# Altium schematic sheet Inverter.SchDoc(Inverter)
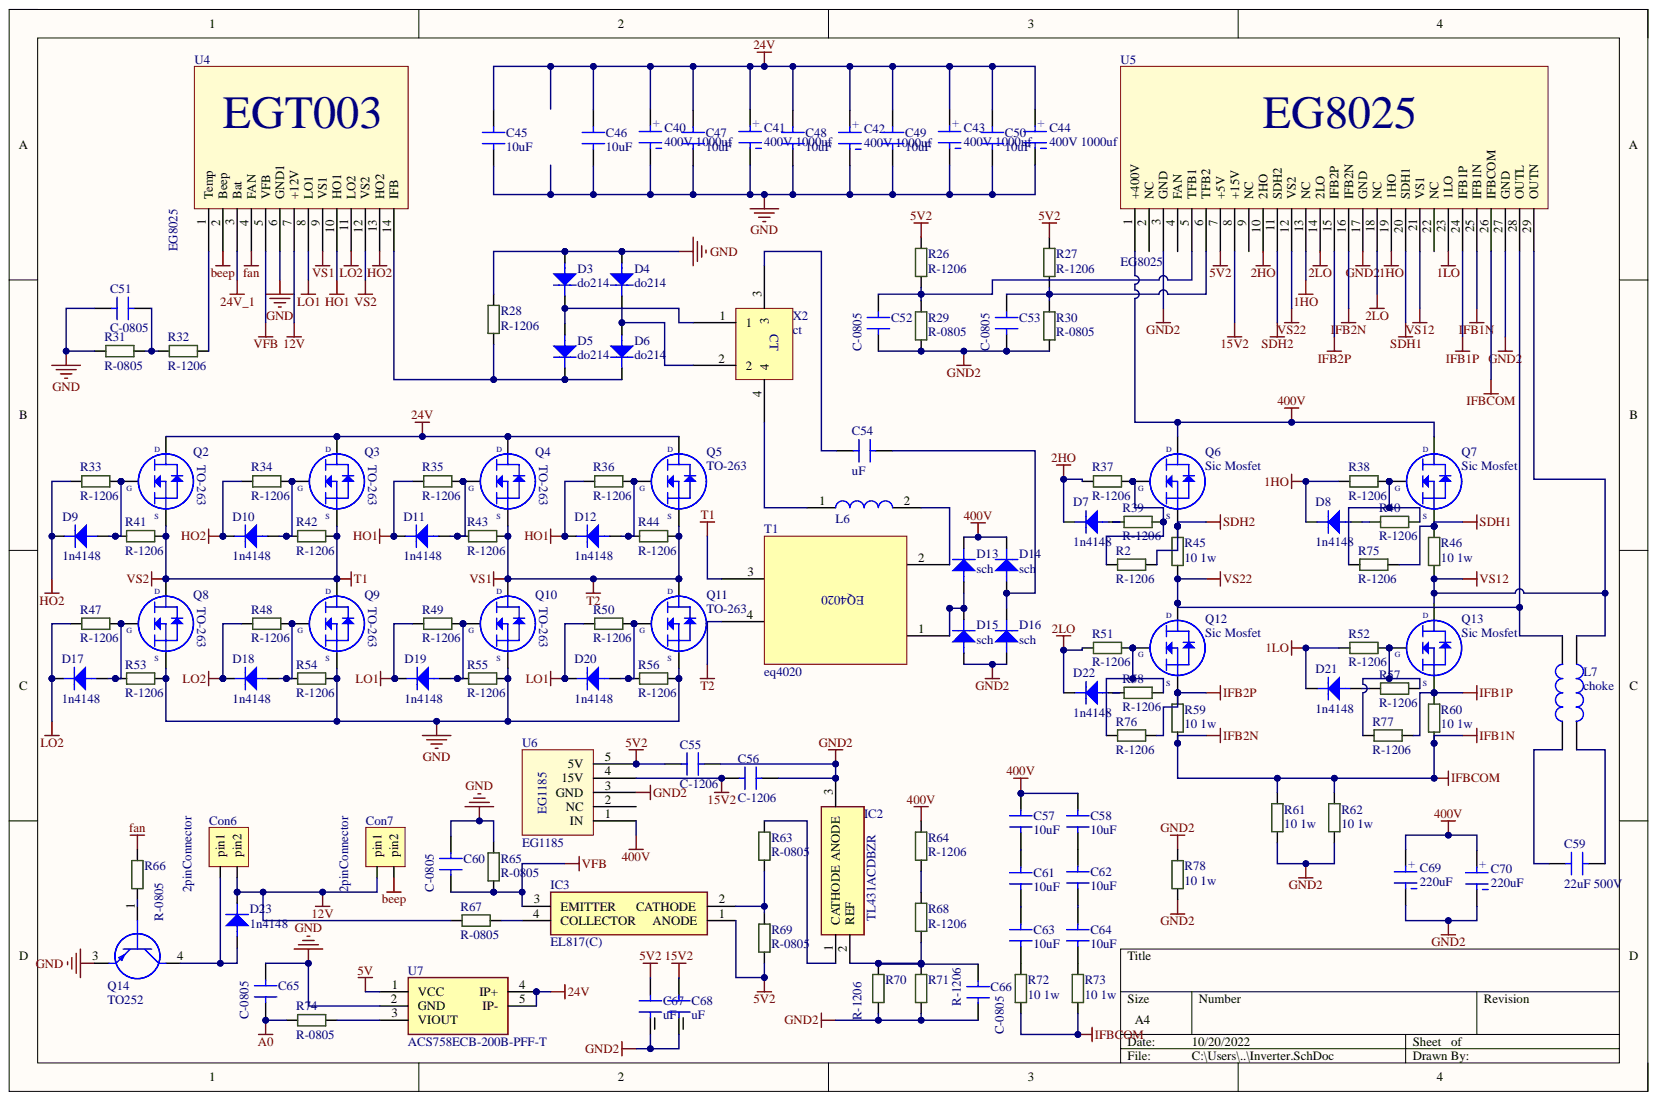
[diagram p0: Inverter.SchDoc]

## Parts
C40  400V 1000uf  [CAP_EEETC1C331V]
C41  400V 1000uf  [CAP_EEETC1C331V]
C42  400V 1000uf  [CAP_EEETC1C331V]
C43  400V 1000uf  [CAP_EEETC1C331V]
C44  400V 1000uf  [CAP_EEETC1C331V]
C45  10uF  [C-2220R]
C46  10uF  [C-2220R]
C47  10uF  [C-2220R]
C48  10uF  [C-2220R]
C49  10uF  [C-2220R]
C50  10uF  [C-2220R]
C51  C-0805  [C-0805W]
C52  C-0805  [C-0805W]
C53  C-0805  [C-0805W]
C54  uF  [680nF bulk]
C55  C-1206  [C-1206W]
C56  C-1206  [C-1206W]
C57  10uF  [C-2220R]
C58  10uF  [C-2220R]
C59  22uF 500V  [CAP.POL 22UF 500VDC - duplicate]
C60  C-0805  [C-0805W]
C61  10uF  [C-2220R]
C62  10uF  [C-2220R]
C63  10uF  [C-2220R]
C64  10uF  [C-2220R]
C65  C-0805  [C-0805W]
C66  C-0805  [C-0805W]
C67  uF  [CapSmdElc]
C68  uF  [CapSmdElc]
C69  220uF  [Koshin]
C70  220uF  [Koshin]
Con6  2pinConnector  [2pinCon]
Con7  2pinConnector  [2pinCon]
D3  do214  [DO-214AC]
D4  do214  [DO-214AC]
D5  do214  [DO-214AC]
D6  do214  [DO-214AC]
D7  1n4148  [SOD123]
D8  1n4148  [SOD123]
D9  1n4148  [SOD123]
D10  1n4148  [SOD123]
D11  1n4148  [SOD123]
D12  1n4148  [SOD123]
D13  sch  [DPAK_TO263 - sch]
D14  sch  [DPAK_TO263 - sch]
D15  sch  [DPAK_TO263 - sch]
D16  sch  [DPAK_TO263 - sch]
D17  1n4148  [SOD123]
D18  1n4148  [SOD123]
D19  1n4148  [SOD123]
D20  1n4148  [SOD123]
D21  1n4148  [SOD123]
D22  1n4148  [SOD123]
D23  1n4148  [SOD123]
IC2  TL431ACDBZR  [SOT95P237X112-3N]
IC3  EL817(C)  [DIP762W60P254L458H480Q4N]
L6  inducter_SMPI06050  [inductor]  (value not drawn)
L7  choke  [choke]
Q2  TO-263  [DPAK_TO263]
Q3  TO-263  [DPAK_TO263]
Q4  TO-263  [DPAK_TO263]
Q5  TO-263  [DPAK_TO263]
Q6  Sic Mosfet  [247]
Q7  Sic Mosfet  [247]
Q8  TO-263  [DPAK_TO263]
Q9  TO-263  [DPAK_TO263]
Q10  TO-263  [DPAK_TO263]
Q11  TO-263  [DPAK_TO263]
Q12  Sic Mosfet  [247]
Q13  Sic Mosfet  [247]
Q14  TO252  [D-PAK_TO252AA]
R2  R-1206  [R-1206W]
R26  R-1206  [R-1206W]
R27  R-1206  [R-1206W]
R28  R-1206  [R-1206W]
R29  R-0805  [R-0805W]
R30  R-0805  [R-0805W]
R31  R-0805  [R-0805W]
R32  R-1206  [R-1206W]
R33  R-1206  [R-1206W]
R34  R-1206  [R-1206W]
R35  R-1206  [R-1206W]
R36  R-1206  [R-1206W]
R37  R-1206  [R-1206W]
R38  R-1206  [R-1206W]
R39  R-1206  [R-1206W]
R40  R-1206  [R-1206W]
R41  R-1206  [R-1206W]
R42  R-1206  [R-1206W]
R43  R-1206  [R-1206W]
R44  R-1206  [R-1206W]
R45  10 1w  [R-2512W]
R46  10 1w  [R-2512W]
R47  R-1206  [R-1206W]
R48  R-1206  [R-1206W]
R49  R-1206  [R-1206W]
R50  R-1206  [R-1206W]
R51  R-1206  [R-1206W]
R52  R-1206  [R-1206W]
R53  R-1206  [R-1206W]
R54  R-1206  [R-1206W]
R55  R-1206  [R-1206W]
R56  R-1206  [R-1206W]
R57  R-1206  [R-1206W]
R58  R-1206  [R-1206W]
R59  10 1w  [R-2512W]
R60  10 1w  [R-2512W]
R61  10 1w  [R-2512W]
R62  10 1w  [R-2512W]
R63  R-0805  [R-0805W]
R64  R-1206  [R-1206W]
R65  R-0805  [R-0805W]
R66  R-0805  [R-0805W]
R67  R-0805  [R-0805W]
R68  R-1206  [R-1206W]
R69  R-0805  [R-0805W]
R70  R-1206  [R-1206W]
R71  R-1206  [R-1206W]
R72  10 1w  [R-2512W]
R73  10 1w  [R-2512W]
R74  R-0805  [R-0805W]
R75  R-1206  [R-1206W]
R76  R-1206  [R-1206W]
R77  R-1206  [R-1206W]
R78  10 1w  [R-2512W]
T1  eq4020  [eq4020]
U4  EG8025  [EGT003]
U5  EG8025  [EG8025]
U6  EG1185  [EG1185]
U7  ACS758ECB-200B-PFF-T  [ACS756SCA-050B-PFF-T_2]
X2  ct  [ct]

## Nets
1HO: D8.k R38.1 U5.13(NC) U5.19(1HO)
1LO: D21.k R52.1 U5.23(1LO)
2HO: D7.k R37.1 U5.10(2HO)
2LO: D22.k R51.1 U5.14(2LO) U5.18(NC)
5V: U7.1(VCC)
5V2: C55.1 C67.1 IC3.1(ANODE) R26.2 R27.2 R69.1 U5.7(+5V) U6.5(5V)
12V: Con6.2 Con7.1 D23.k R67.1 U4.7(+12V)
15V2: C56.1 C68.1 U5.8(+15V) U6.4(15V)
24V: C40.1 C41.1 C42.1 C43.1 C44.1 C45.1 C46.1 C47.1 C48.1 C49.1 C50.1 Q2.3 Q3.3 Q4.3 Q5.3 U7.4(IP+) U7.5(IP-)
24V_1: U4.3(Bat)
400V: C57.1 C58.1 C69.2 C70.2 D13.2 D14.2 Q6.2 Q7.2 R64.2 U5.1(+400V) U6.1(IN)
A0: C65.1 R74.2
beep: Con7.2 U4.2(Beep)
fan: R66.1 U4.4(FAN)
GND: C40.2 C41.2 C42.2 C43.2 C44.2 C45.2 C46.2 C47.2 C48.2 C49.2 C50.2 C51.1 C60.2 C65.2 D3.a D4.a Q8.2 Q9.2 Q10.2 Q11.2 Q14.3 R28.2 R31.1 R53.2 R54.2 R55.2 R56.2 R65.2 U4.6(GND1) U7.2(GND)
GND2: C52.1 C53.1 C55.2 C56.2 C66.1 C67.2 C68.2 C69.1 C70.1 D15.1 D16.1 IC2.3 R29.1 R30.1 R61.1 R62.1 R70.1 R71.1 R78.1 R78.2 U5.3(GND) U5.17(GND) U5.27(GND) U6.3(GND)
HO1: D11.k D12.k R35.1 R36.1 U4.10(HO1)
HO2: D9.k D10.k R33.1 R34.1 U4.13(HO2)
IFB1N: R59.1 R60.1 R61.2 R62.2 R72.1 R73.1 U5.16(IFB2N) U5.25(IFB1N) U5.26(IFBCOM)
IFB1P: Q13.3 R60.2 R77.1 U5.24(IFB1P)
IFB2P: Q12.3 R59.2 R76.1 U5.15(IFB2P)
LO1: D19.k D20.k R49.1 R50.1 U4.8(LO1)
LO2: D17.k D18.k R47.1 R48.1 U4.11(LO2)
SDH1: Q7.3 R46.2 R75.1 U5.20(SDH1)
SDH2: Q6.3 R2.1 R45.2 U5.11(SDH2)
T1: Q2.2 Q3.2 Q8.3 Q9.3 R41.2 R42.2 T1.3 U4.12(VS2)
T2: Q4.2 Q5.2 Q10.3 Q11.3 R43.2 R44.2 T1.4 U4.9(VS1)
VFB: C60.1 IC3.3(EMITTER) R65.1 U4.5(VFB)
VS12: L7.1 Q13.2 R46.1 U5.21(VS1) U5.29(OUTN)
VS22: L7.2 Q12.2 R45.1 U5.12(VS2) U5.28(OUTL)
NetC51_2: C51.2 R31.2 R32.1
NetC52_2: C52.2 R26.1 R29.2 U5.5(TFB1)
NetC53_2: C53.2 R27.1 R30.2 U5.6(TFB2)
NetC54_1: C54.1 X2.3
NetC54_2: C54.2 D14.1 D16.2
NetC57_2: C57.2 C61.1
NetC58_2: C58.2 C62.1
NetC59_1: C59.1 L7.4
NetC59_2: C59.2 L7.3
NetC61_2: C61.2 C63.1
NetC62_2: C62.2 C64.1
NetC63_2: C63.2 R72.2
NetC64_2: C64.2 R73.2
NetC66_2: C66.2 IC2.2 R68.1 R70.2 R71.2
NetCon6_1: Con6.1 D23.a Q14.4
NetD3_k: D3.k D5.a X2.1
NetD4_k: D4.k D6.a X2.2
NetD5_k: D5.k D6.k R28.1 U4.14(IFB)
NetD7_a: D7.a R39.1
NetD8_a: D8.a R40.1
NetD9_a: D9.a Q2.1 R33.2 R41.1
NetD10_a: D10.a Q3.1 R34.2 R42.1
NetD11_a: D11.a Q4.1 R35.2 R43.1
NetD12_a: D12.a Q5.1 R36.2 R44.1
NetD13_1: D13.1 D15.2 T1.1
NetD17_a: D17.a Q8.1 R47.2 R53.1
NetD18_a: D18.a Q9.1 R48.2 R54.1
NetD19_a: D19.a Q10.1 R49.2 R55.1
NetD20_a: D20.a Q11.1 R50.2 R56.1
NetD21_a: D21.a R57.1
NetD22_a: D22.a R58.1
NetIC2_1: IC2.1 R63.1
NetIC3_2: IC3.2(CATHODE) R63.2 R69.2
NetIC3_4: IC3.4(COLLECTOR) R67.2
NetL6_1: L6.1 X2.4
NetL6_2: L6.2 T1.2
NetQ6_1: Q6.1 R2.2 R37.2 R39.2
NetQ7_1: Q7.1 R38.2 R40.2 R75.2
NetQ12_1: Q12.1 R51.2 R58.2 R76.2
NetQ13_1: Q13.1 R52.2 R57.2 R77.2
NetQ14_1: Q14.1 R66.2
NetR32_2: R32.2 U4.1(Temp)
NetR64_1: R64.1 R68.2
NetR74_1: R74.1 U7.3(VIOUT)

Not connected: U5 2(NC) 4(FAN) 9(NC) 22(NC); U6 2(NC)

## Library data of the parts
C40-C44 = Aluminum Electrolytic Capacitors: Manufacturer: Nichicon; Manufacturer Part Number: LKS1J472MESZ; Capacitance: 4.7mF; Life (Hours): 1000Hour; Ripple Current: 3A; Tolerance: 20%; Voltage Rating: 63V; Case/Package: Radial; Component Kind: Standard; ComponentLink2Description: https://www.ozdisan.com/pasif-komponentler/kondansatorler/aluminyum-kondansatorler/PKL5-063V472MM450; ComponentLink2URL: https://www.ozdisan.com/pasif-komponentler/kondansatorler/aluminyum-kondansatorler/PKL5-063V472MM450; Diameter: 22mm; Height - Seated (Max): 1.772inch; Lead Pitch: 0.394inch; Length: 45mm; Library Name: Schlib1.SchLib; Max Operating Temperature: 85°C; Min Operating Temperature: -40°C; Mount: Through Hole; Package Quantity: 250; Packaging: Bulk; RoHS: Yes; Supplier 1: Mouser; Supplier Part Number 1: 647-LKS1J472MESZ; Termination: Snap in; Voltage Rating (DC): 63V
C45-C50 C57 C58 C61-C64 = Cap2220: 2220; Manufacturer: SAMSUNG; Özdisan Part Number: CL31B475KBHNNNE; Component Kind: Standard; Library Name: Schlib1.SchLib; Link: https://www.ozdisan.com/pasif-komponentler/kondansatorler/smd-kondansatorler-mlcc/CL31B475KBHNNNE; Price: 0,10407
C54 = 680: Component Kind: Standard; Library Name: Schlib1.SchLib
C59 = CAP.POL 22UF 500VDC: Component Kind: Standard; ComponentLink1Description: https://www.ozdisan.com/pasif-komponentler/kondansatorler/film-kondansatorler/C3D2H226JB00C00; ComponentLink1URL: https://www.ozdisan.com/pasif-komponentler/kondansatorler/film-kondansatorler/C3D2H226JB00C00; Library Name: Schlib1.SchLib
C67 C68 = CAPSMDELC: Component Kind: Standard; Library Name: Schlib1.SchLib
C69 C70 = CAP.EL.220uF 500V: Component Kind: Standard; ComponentLink1Description: https://www.ozdisan.com/pasif-komponentler/kondansatorler/aluminyum-kondansatorler/K055002210PM0E040; ComponentLink1URL: https://www.ozdisan.com/pasif-komponentler/kondansatorler/aluminyum-kondansatorler/K055002210PM0E040; Library Name: Schlib1.SchLib
D3-D6 = do214: DIODE FAST SWITCHING Single 150mA 75V SMT SOD323; Component Kind: Standard; Library Name: Schlib1.SchLib
D7-D12 D17-D23 = 1N4148W-E3-18: Diode Small Signal Switching 100V 0.15A 2-Pin SOD-123 T/R; Manufacturer: Vishay; Average Rectified Current: 150mA; Element Configuration: Single; Forward Voltage: 1.2V; Max Power Dissipation: 350mW; Max Reverse Leakage Current: 5uA; Max Reverse Voltage (DC): 75V; Max Surge Current: 2A; Peak Reverse Current: 100uA; Recovery Time: 4ns; Component Kind: Standard; ComponentLink1Description: https://datasheet.ciiva.com/29885/1n4148w-29885941.pdf?src-supplier=Avnet; ComponentLink1URL: https://datasheet.ciiva.com/29885/1n4148w-29885941.pdf?src-supplier=Avnet; ComponentLink2Description: https://datasheet.ciiva.com/29593/1n4148w-29593113.pdf?src-supplier=Digi-Key; ComponentLink2URL: https://datasheet.ciiva.com/29593/1n4148w-29593113.pdf?src-supplier=Digi-Key; ComponentLink3Description: https://datasheet.ciiva.com/29593/1n4148w-29593111.pdf?src-supplier=Digi-Key; ComponentLink3URL: https://datasheet.ciiva.com/29593/1n4148w-29593111.pdf?src-supplier=Digi-Key; ComponentLink4Description: https://datasheet.ciiva.com/29593/1n4148w-29593112.pdf?src-supplier=Digi-Key; ComponentLink4URL: https://datasheet.ciiva.com/29593/1n4148w-29593112.pdf?src-supplier=Digi-Key; Contact Plating: Tin; Forward Current: 150mA; Height: 1.25mm; Lead Free: Lead Free; Length: 2.85mm; Library Name: Schlib1.SchLib; Manufacturer Part Number: 1N4148W-E3-18; Max Operating Temperature: 150°C; Max Repetitive Reverse Voltage (Vrrm): 100V; Min Operating Temperature: -55°C; Mount: Surface Mount; Number of Pins: 2; Package Quantity: 10000; Packaging: Tape and Reel; Peak Non-Repetitive Surge Current: 2A; Power Dissipation: 350mW; Pricing 1: 1 = 0.03900, 25 = 0.03800, 100 = 0.03600, 250 = 0.03400, 500 = 0.03200, 1000 = 0.03100, 2500 = 0.02900 (USD); Radiation Hardening: No; Reverse Recovery Time: 4ns; RoHS: Yes; Stock 1: 6838; Supplier 1: Allied Electronics; Supplier Part Number 1: 70061597; Weight: 0.000363oz; Width: 1.7mm
D13-D16 = sch: DIODE FAST SWITCHING Single 150mA 75V SMT SOD323; Component Kind: Standard; Library Name: Schlib1.SchLib
IC2 = TL431ACDBZR: Integrated Circuit; Manufacturer_Name: Texas Instruments; Arrow Part Number: TL431ACDBZR; Arrow Price/Stock: https://www.arrow.com/en/products/tl431acdbzr/texas-instruments?region=nac; Component Kind: Standard; Datasheet Link: https://datasheet.datasheetarchive.com/originals/distributors/SFDatasheet-6/sf-000131849.pdf; Manufacturer_Part_Number: TL431ACDBZR; Mouser Part Number: 595-TL431ACDBZR; Mouser Price/Stock: https://www.mouser.co.uk/ProductDetail/Texas-Instruments/TL431ACDBZR?qs=odmYgEirbwzMcBuFx%2Fo8hQ%3D%3D
IC3 = EL817(C): Integrated Circuit; Manufacturer_Name: Everlight; Component Kind: Standard; Datasheet Link: https://componentsearchengine.com/Datasheets/1/EL817(C).pdf; Height: 4.8mm; Library Name: Schlib1.SchLib; Manufacturer_Part_Number: EL817(C); Mouser Part Number: 638-EL817C; Mouser Price/Stock: https://www.mouser.com/Search/Refine.aspx?Keyword=638-EL817C
Q2-Q5 Q8-Q11 = TO-263_1: Component Kind: Standard; Library Name: Schlib1.SchLib
Q6 Q7 Q12 Q13 = Sic Mosfet To247: Component Kind: Standard; Description: SiC Mosfet To247; Library Name: Schlib1.SchLib
R45 R46 R59-R62 R72 R73 R78 = R-2512: Component Kind: Standard; Description: 10 1w; Library Name: Schlib1.SchLib
U4 = EGT003: Component Kind: Standard; Library Name: Schlib1.SchLib
U7 = ACS758ECB-200B-PFF-T: Undefined or Miscellaneous; Manufacturer_Name: Allegro Microsystems; Arrow Part Number: ACS758ECB-200B-PFF-T; Arrow Price/Stock: https://www.arrow.com/en/products/acs758ecb-200b-pff-t/allegro-microsystems; Component Kind: Standard; Datasheet Link: http://www.farnell.com/datasheets/926109.pdf; Library Name: Schlib1.SchLib; Manufacturer_Part_Number: ACS758ECB-200B-PFF-T
C51-C53 C60 C65 C66 = C-0805: Component Kind: Standard; Library Name: Schlib1.SchLib
C55 C56 = C-1206: Component Kind: Standard; Library Name: Schlib1.SchLib
Con6 Con7 = 2pinConnector: Component Kind: Standard; Library Name: Schlib1.SchLib
L6 = inducter_SMPI06050: Component Kind: Standard; Library Name: Schlib1.SchLib
L7 = choke: Component Kind: Standard; Library Name: Schlib1.SchLib
Q14 = TO252: Component Kind: Standard; Library Name: Schlib1.SchLib
R2 R26-R28 R32-R44 R47-R58 R64 R68 R70 R71 R75-R77 = R-1206: Component Kind: Standard; Library Name: Schlib1.SchLib
R29-R31 R63 R65-R67 R69 R74 = R-0805: Component Kind: Standard; Library Name: Schlib1.SchLib
T1 = eq4020: Component Kind: Standard; Library Name: Schlib1.SchLib
U5 = eg8025: Component Kind: Standard; Library Name: Schlib1.SchLib
U6 = eg1185: Component Kind: Standard; Library Name: Schlib1.SchLib
X2 = ct: Component Kind: Standard; Library Name: Schlib1.SchLib
